# Altium schematic sheet P01_Power.SchDoc
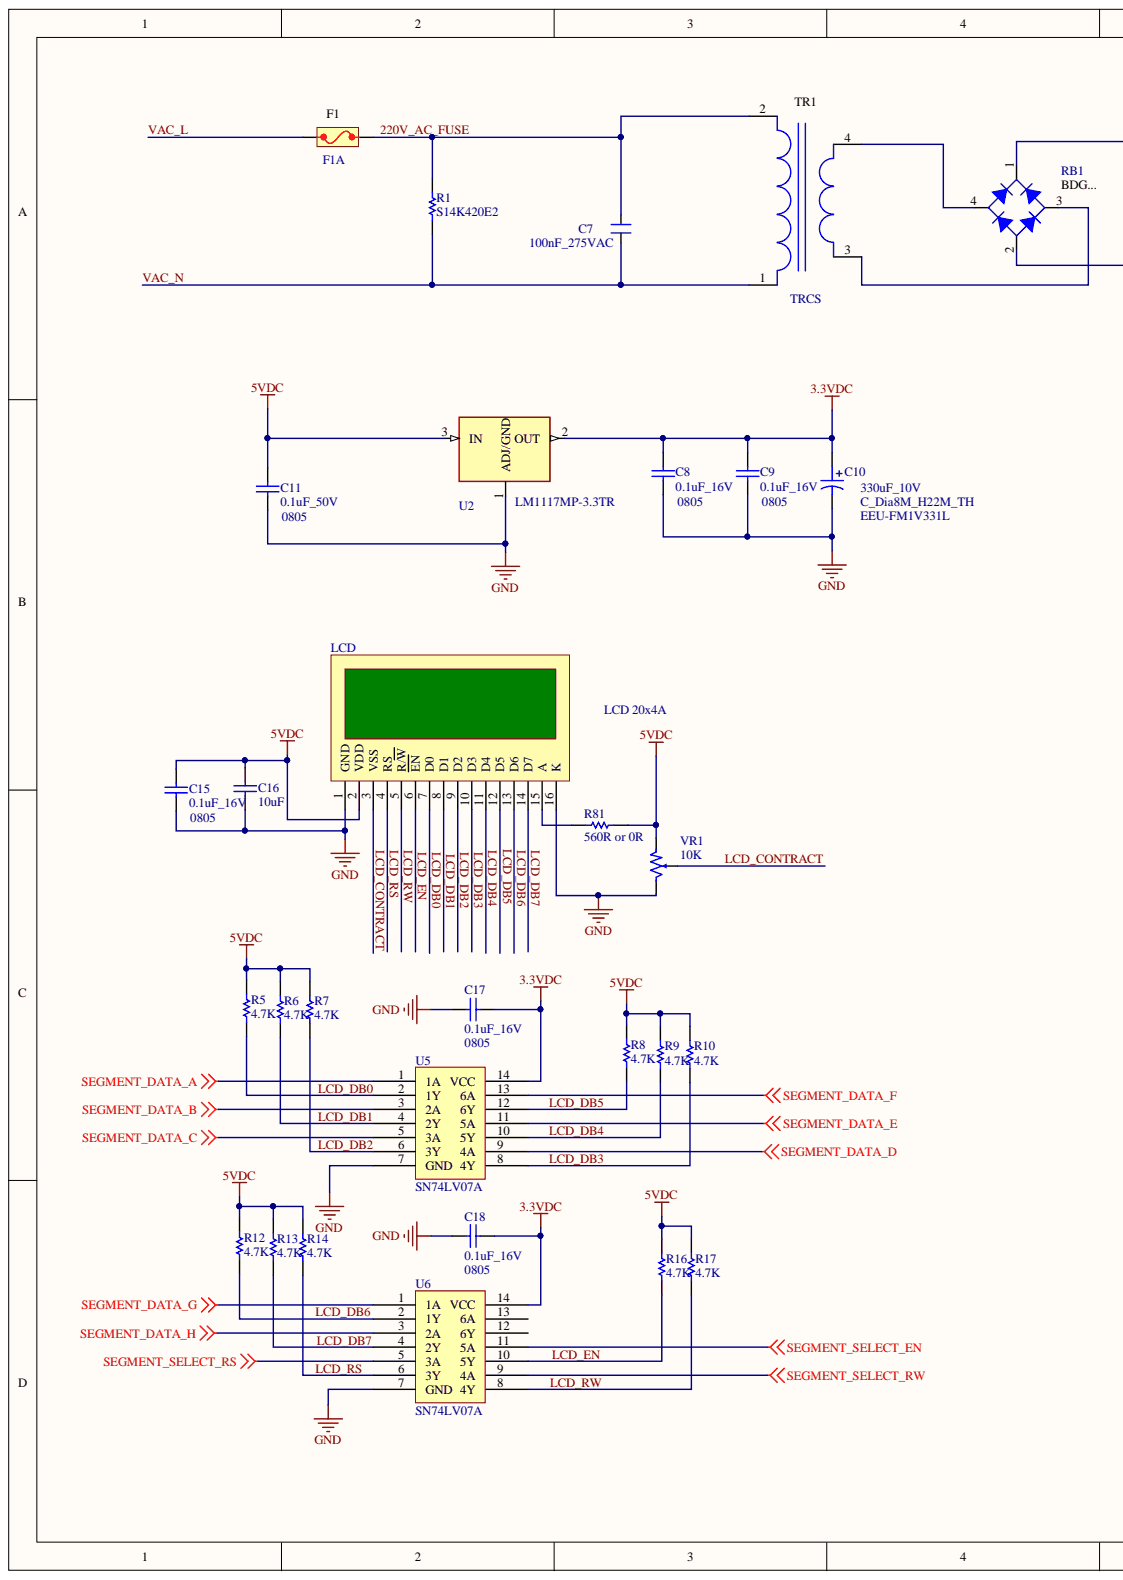
[diagram p0: P01_Power.SchDoc, left]
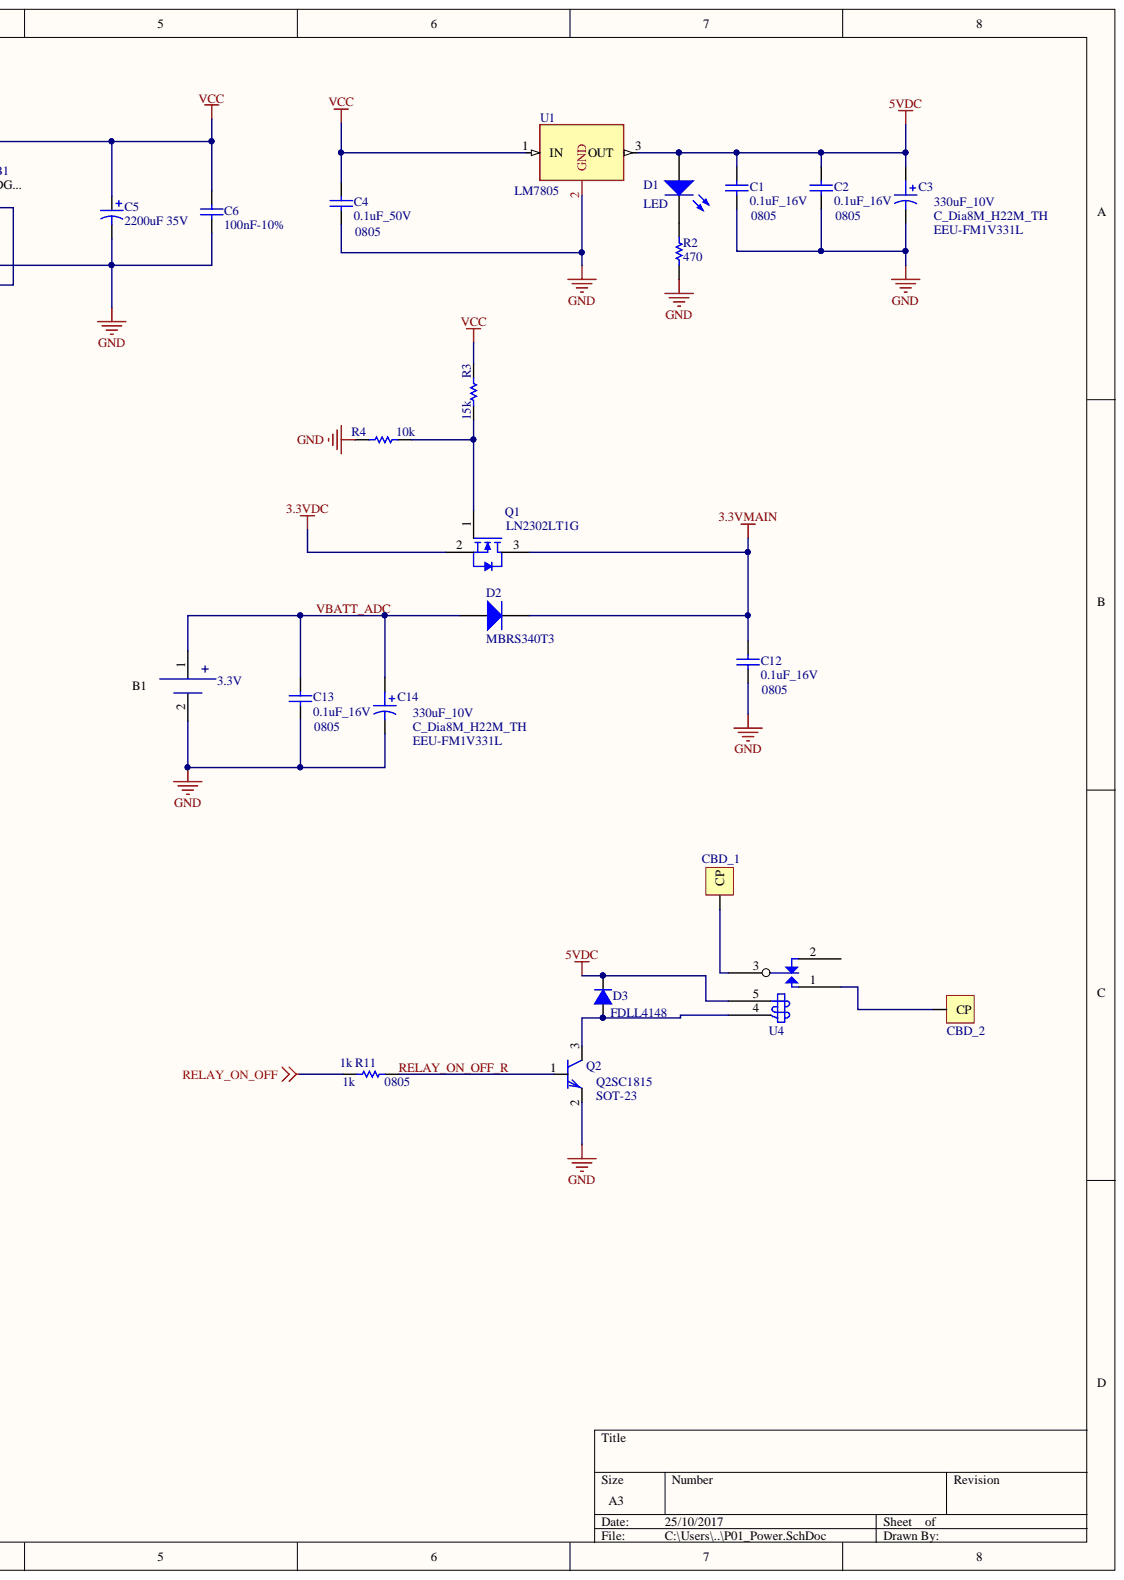
[diagram p1: P01_Power.SchDoc, right]

## Parts
B1  value 3.3V  [Pin]  (hidden comment: BATT-Holder)
C1  0.1uF_16V  PCB_Footprint: 0805  [C-NP-0805]
C2  0.1uF_16V  PCB_Footprint: 0805  [C-NP-0805]
C3  MFR_PART: EEU-FM1V331L  Part: 330uF_10V  PCB_Footprint: C_Dia8M_H22M_TH  [CAP 470MF/16V]  (hidden comment: 330uF_35V)
C4  0.1uF_50V  PCB_Footprint: 0805  [C-NP-0805]
C5  2200uF 35V  [CAP 4700MF/16V]
C6  100nF-10%  [C-NP-0805]
C7  100nF_275VAC  [Res 10mm (1/2W)]
C8  0.1uF_16V  PCB_Footprint: 0805  [C-NP-0805]
C9  0.1uF_16V  PCB_Footprint: 0805  [C-NP-0805]
C10  MFR_PART: EEU-FM1V331L  Part: 330uF_10V  PCB_Footprint: C_Dia8M_H22M_TH  [CAP 470MF/16V]  (hidden comment: 330uF_35V)
C11  0.1uF_50V  PCB_Footprint: 0805  [C-NP-0805]
C12  0.1uF_16V  PCB_Footprint: 0805  [C-NP-0805]
C13  0.1uF_16V  PCB_Footprint: 0805  [C-NP-0805]
C14  MFR_PART: EEU-FM1V331L  Part: 330uF_10V  PCB_Footprint: C_Dia8M_H22M_TH  [CAP 470MF/16V]  (hidden comment: 330uF_35V)
C15  0.1uF_16V  PCB_Footprint: 0805  [C-NP-0805]
C16  10uF  [C-NP-0805]
C17  0.1uF_16V  PCB_Footprint: 0805  [C-NP-0805]
C18  0.1uF_16V  PCB_Footprint: 0805  [C-NP-0805]
CBD_1  Connect Pad  [CP]  (value not drawn)
CBD_2  Connect Pad  [CP]  (value not drawn)
D1  LED  [LED-0805 BLUE]
D2  MBRS340T3  [DO-214AA,SMB]
D3  FDLL4148  [SOD80]
F1  FUSE  value F1A  [FUSE radial - D8.5-H7.5]
LCD  LCD 20x4A  [LCD20x4]
Q1  LN2302LT1G  [SOT-23]
Q2  C1815  [SOT-23]
R1  S14K420E2  [Resistor]
R2  470  [R-0805]
R3  15k  [R-0805]
R4  10k  [R-0805]
R5  4.7K  [R-0805]
R6  4.7K  [R-0805]
R7  4.7K  [R-0805]
R8  4.7K  [R-0805]
R9  4.7K  [R-0805]
R10  4.7K  [R-0805]
R11  1k  PCB_Footprint: 0805  [R-0805]
R12  4.7K  [R-0805]
R13  4.7K  [R-0805]
R14  4.7K  [R-0805]
R16  4.7K  [R-0805]
R17  4.7K  [R-0805]
R81  value 560R or 0R  [R-0805]  (hidden comment: Resistor)
RB1  value BDG...  [DB107S]  (hidden comment: Diode Bridge)
TR1  TRCS  [TR12V]
U1  LM7805  [TO-220]
U2  LM1117MP-3.3TR  [SOT-223 3-Lead]
U4  RELAY5V  [Relay5V]  (value not drawn)
U5  SN74LV07A  [SOIC14]
U6  SN74LV07A  [SOIC14]
VR1  10K  [Trimmer]

## Nets
3.3VDC: C8.2 C9.2 C10.1 C17.1 C18.1 Q1.2 U2.2 U5.14(VCC) U6.14(VCC)
3.3VMAIN: C12.2 D2.2 Q1.3
5VDC: C1.2 C2.2 C3.1 C11.2 C15.2 C16.2 D1.1 D3.2 LCD.2(VDD) R5.2 R6.2 R7.2 R8.2 R9.2 R10.2 R12.2 R13.2 R14.2 R16.2 R17.2 R81.2 U1.3 U2.3 U4.5 VR1.1
GND: B1.2 C1.1 C2.1 C3.2 C4.1 C5.2 C6.1 C8.1 C9.1 C10.2 C11.1 C12.1 C13.1 C14.2 C15.1 C16.1 C17.2 C18.2 LCD.1(GND) LCD.16(K) Q2.2 R2.1 R4.1 RB1.2 U1.2 U2.1 U5.7(GND) U6.7(GND) VR1.3
RELAY_ON_OFF: R11.1
SEGMENT_DATA_A: U5.1(1A)
SEGMENT_DATA_B: U5.3(2A)
SEGMENT_DATA_C: U5.5(3A)
SEGMENT_DATA_D: U5.9(4A)
SEGMENT_DATA_E: U5.11(5A)
SEGMENT_DATA_F: U5.13(6A)
SEGMENT_DATA_G: U6.1(1A)
SEGMENT_DATA_H: U6.3(2A)
SEGMENT_SELECT_EN: U6.11(5A)
SEGMENT_SELECT_RS: U6.5(3A)
SEGMENT_SELECT_RW: U6.9(4A)
VCC: C4.2 C5.1 C6.2 R3.1 RB1.1 U1.1
220V_AC_FUSE: C7.2 F1.2 R1.1 TR1.2
LCD_CONTRACT: LCD.3(VSS) VR1.2
LCD_DB0: LCD.7(D0) R5.1 U5.2(1Y)
LCD_DB1: LCD.8(D1) R6.1 U5.4(2Y)
LCD_DB2: LCD.9(D2) R7.1 U5.6(3Y)
LCD_DB3: LCD.10(D3) R10.1 U5.8(4Y)
LCD_DB4: LCD.11(D4) R9.1 U5.10(5Y)
LCD_DB5: LCD.12(D5) R8.1 U5.12(6Y)
LCD_DB6: LCD.13(D6) R12.1 U6.2(1Y)
LCD_DB7: LCD.14(D7) R13.1 U6.4(2Y)
LCD_EN: LCD.6(EN) R16.1 U6.10(5Y)
LCD_RS: LCD.4(RS) R14.1 U6.6(3Y)
LCD_RW: LCD.5(R/W) R17.1 U6.8(4Y)
RELAY_ON_OFF_R: Q2.1 R11.2
VAC_L: F1.1
VAC_N: C7.1 R1.2 TR1.1
VBATT_ADC: B1.1 C13.2 C14.1 D2.1
NetCBD_1_1: CBD_1.1 U4.3
NetCBD_2_1: CBD_2.1 U4.1
NetD1_2: D1.2 R2.2
NetD3_1: D3.1 Q2.3 U4.4
NetLCD_15: LCD.15(A) R81.1
NetQ1_1: Q1.1 R3.2 R4.2
NetRB1_3: RB1.3 TR1.3
NetRB1_4: RB1.4 TR1.4

Not connected: U4 2; U6 12(6Y) 13(6A)

## Library data of the parts
B1 = BATT-Holder: Battery holder; Manufacturer: China; Vendor: Digikey; Component Kind: Standard; ComponentLink1Description: Digikey Part Link; ComponentLink2Description: Open Datasheet; Price: 3000 VND; Published: 13-April-2009; ComponentLink2URL: H:\LIBRARY\LIBRARY.Datasheet\; Library Name: hphonglib.SchLib; Publisher: Hoang Nguyen
C1 C2 C4 C8 C9 C11-C13 C15 C17 C18 = Cap Non-pol: Non-polarized Capacitor; Component Kind: Standard; Library Name: hphonglib.SchLib
  C1 C2 C8 C9 C12 C13 C15 C17 C18: Part: 0.1uF_16V
  C4 C11: Part: 0.1uF_50V
C3 C10 C14 = Cap Pol: Polarized Capacitor; Component Kind: Standard; Library Name: hphonglib.SchLib
C5 = 4.7uF-50V-Tantalum: CAP ALUM 2.2UF 400V 20% RADIAL; Manufacturer: Nichicon; Manufacturer Part Number: UVY2G2R2MPD1TA; Component Kind: Standard; Digi-Key Part Number: UVY2G2R2MPD1TA-ND; Library Name: Capacitor.SchLib; Unit Price: 0.07
C6 = 100nF-50V-C0805: Non-Polarized Capacitor; Manufacturer: Yageo; Manufacturer Part Number: CC0805KRX7R9BB104; Component Kind: Standard; Digi-Key Part Number: 311-1140-1-ND; Library Name: Capacitor.SchLib; Unit Price: 0.1; Value: 100nF-10%
C7 = CM: Cap SMD; Component Kind: Standard; Library Name: syhaunguyen.SchLib
C16 = 100nF-50V-C0805: Non-Polarized Capacitor; Manufacturer: Yageo; Manufacturer Part Number: CC0805KRX7R9BB104; Value: 1uF; Component Kind: Standard; Digi-Key Part Number: 311-1140-1-ND; Library Name: Capacitor.SchLib; Unit Price: 0.1
CBD_1 CBD_2 = Connect Pad: Connect Pad, 1-Pin; Component Kind: Standard; LatestRevisionDate: 17-Jul-2002; LatestRevisionNote: Re-released for DXP Platform.; Library Name: phong.SchLib; Publisher: Altium Limited
D1 = LED: Typical LED; Component Kind: Standard; LatestRevisionDate: 08-Jul-2005; LatestRevisionNote: Mech Layer 1 removed.; PackageReference: LED-1; Published: 8-Jun-2000; Library Name: hphonglib.SchLib; Publisher: Altium Limited
D2 = Diode 1N4148: High Conductance Fast Diode; Code_JEDEC: DO-204-AH; Component Kind: Standard; ComponentLink1Description: Manufacturer Link; ComponentLink1URL: http://www.fairchildsemi.com/; ComponentLink2Description: Datasheet; ComponentLink2URL: http://www.fairchildsemi.com/ds/1N%2F1N4148.pdf; DatasheetVersion: 1997; LatestRevisionDate: 17-Jul-2002; LatestRevisionNote: Re-released for DXP Platform.; PackageDescription: Diode, Axial; Body 3.8 x 1.9 mm (LxDia. max), Lead Dia. 0.53 mm (max); PackageReference: DO-35; Published: 8-Jun-2000; Signal Integrity: 1N4148; Simulation: 1N4148; Library Name: hphonglib.SchLib; Publisher: Altium Limited
D3 = FDLL4148: DIODE GEN PURP 100V 200MA LL34; Manufacturer: Fairchild Semiconductor; Capacitance @ Vr, F: 4pF @ 0V, 1MHz; Current - Reverse Leakage @ Vr: 5µA @ 75V; Diode Type: Standard; Reverse Recovery Time (trr): 4ns; Speed: Small Signal =< 200mA (Io), Any Speed; Voltage - Forward (Vf) (Max) @ If: 1V @ 10mA; Category: Discrete Semiconductor Products; Component Kind: Standard; ComponentLink1Description: http://www.fairchildsemi.com/datasheets/FD/FDLL4148.pdf; ComponentLink1URL: http://www.fairchildsemi.com/datasheets/FD/FDLL4148.pdf; Current - Average Rectified (Io): 200mA; Library Name: Diode.SchLib; Manufacturer Part Number: FDLL4148; Mounting Type: Surface Mount; Operating Temperature - Junction: -65°C ~ 175°C; Package / Case: DO-213AC, MINI-MELF, SOD-80; Packaging: Cut Tape (CT); Pricing 1: 1=0.1, 10=0.091, 100=0.0494, 500=0.03036, 1000=0.0207 (USD); RoHS: RoHS Compliant; Stock 1: 286836; Supplier 1: Digi-Key; Supplier Device Package: LL-34; Supplier Part Number 1: FDLL4148CT-ND; Voltage - DC Reverse (Vr) (Max): 100V
F1 = FUSE_1: Vendor: Digikey; Component Kind: Standard; ComponentLink1Description: Digikey Part Link; ComponentLink2Description: Open Datasheet; Description: FUSE; Published: 13-April-2009; ComponentLink2URL: H:\LIBRARY\LIBRARY.Datasheet\; Library Name: hphonglib.SchLib; Publisher: Hoang Nguyen
LCD = LCD20x4A: LCD dipslay 20 char, 4 line; Value: Jum 40; Component Kind: Standard; Value: LCD 20x4A; Library Name: syhaunguyen.SchLib
Q1 = 2N7002: N-Channel Enhancement Mode FET; Vendor: Digikey; Component Kind: Standard; ComponentLink1Description: Digikey Part Link; ComponentLink2Description: Open Datasheet; DatasheetDocument: Rev A1, 1997; Published: 5-Jan-2004; ComponentLink2URL: H:\LIBRARY\LIBRARY.Datasheet\; Library Name: hphonglib.SchLib; Publisher: Altium Limited
Q2 = C1815: Q2SC1815; Component Kind: Standard; Part: Q2SC1815; PCB_Footprint: SOT-23; Simulation: Q2SC1815; Library Name: hphonglib.SchLib
R1-R14 R16 R17 = Resistor: Component Kind: Standard; Library Name: hphonglib.SchLib
  R1: Description: Resistor; LatestRevisionDate: 17-Jul-2002; LatestRevisionNote: Re-released for DXP Platform.; PackageReference: AXIAL-0.3; Published: 8-Jun-2000; Value: S14K420E2
  R2: Description: Resistor; LatestRevisionDate: 17-Jul-2002; LatestRevisionNote: Re-released for DXP Platform.; PackageReference: AXIAL-0.3; Published: 8-Jun-2000; Value: 470
  R3: Description: Resistor; LatestRevisionDate: 17-Jul-2002; LatestRevisionNote: Re-released for DXP Platform.; PackageReference: AXIAL-0.3; Published: 8-Jun-2000; Value: 15k
  R4: Description: Resistor; LatestRevisionDate: 17-Jul-2002; LatestRevisionNote: Re-released for DXP Platform.; PackageReference: AXIAL-0.3; Published: 8-Jun-2000; Value: 10k
  R5-R10 R12-R14 R16 R17: Description: Resistor; LatestRevisionDate: 17-Jul-2002; LatestRevisionNote: Re-released for DXP Platform.; PackageReference: AXIAL-0.3; Published: 8-Jun-2000; Value: 4.7K
  R11: Part: 1k
  R1-R10 R12-R14 R16 R17: Publisher: Altium Limited
RB1 = Diode_Bridge: Rectifier Bridge; Vendor: Digikey; Component Kind: Standard; ComponentLink1Description: Digikey Part Link; ComponentLink1URL: http://www.irf.com/; ComponentLink2Description: Open Datasheet; ComponentLink2URL: http://www.irf.com/product-info/datasheets/data/1kab-e.pdf; DatasheetDocument: E2732B; LatestRevisionDate: 17-Jul-2002; LatestRevisionNote: Re-released for DXP Platform.; PackageReference: D-38; Published: 8-Jun-2000; Library Name: phong.SchLib; Publisher: Altium Limited
TR1 = TRCS: Power 3VA - Body 40x32 mm; Vendor: Digikey; Component Kind: Standard; ComponentLink1Description: Digikey Part Link; ComponentLink2Description: Open Datasheet; Published: 13-April-2009; ComponentLink2URL: H:\LIBRARY\LIBRARY.Datasheet\; Library Name: phong.SchLib; Publisher: Hoang Nguyen
U1 = LM78xx: Regulator; Manufacturer: Fairchild Semiconductor Corporation; Output Current: Up to 1A; PSpiceTemplate: X^@REFDES %IN %OUT %GND @MODEL; Tolerance: 4 %; Value: LM7805CT; Vendor: Digikey; V out: 5V; Component Kind: Standard; ComponentLink1Description: Digikey Part Link; ComponentLink2Description: Open Datasheet; Price: 2500 VND; Published: 13-April-2009; Simulation: LM7805C; ComponentLink2URL: H:\LIBRARY\LIBRARY.Datasheet\LM7805.pdf; Library Name: phong.SchLib; Publisher: Hoang Nguyen
U2 = LM1117MP-3.3TR: 3.3V 800mA Low-Dropout Linear Regulator; PSpiceTemplate: X^@REFDES %IN %OUT %GND @MODEL; Vendor: Digikey; Volt (Max): 20V; Component Kind: Standard; ComponentLink1Description: Digikey Part Link; ComponentLink1URL: http://search.digikey.com/scripts/DkSearch/dksus.dll?Detail&name=LM1117MP-3.3TR-ND; ComponentLink2Description: Open Datasheet; Output Current (max): 800mA; Price: 4.000 VND; Published: 13-April-2009; V out: 3.3V; ComponentLink2URL: H:\LIBRARY\LIBRARY.Datasheet\LM1117.pdf; Library Name: hphonglib.SchLib; Publisher: Hoang Nguyen
U4 = RELAY5V: Ro le 5V; Vendor: Digikey; Component Kind: Standard; ComponentLink1Description: Digikey Part Link; ComponentLink2Description: Open Datasheet; Published: 13-April-2009; ComponentLink2URL: H:\LIBRARY\LIBRARY.Datasheet\; Library Name: hphonglib.SchLib; Publisher: Hoang Nguyen
U5 U6 = 74HC04P1: Component Kind: Standard; Library Name: 74HC04P1.SchLib
VR1 = VR1: Var Resistor; Value: VR 10K; Component Kind: Standard; Library Name: syhaunguyen.SchLib
R81 = Resistor: Component Kind: Standard; Description: Resistor; LatestRevisionDate: 17-Jul-2002; LatestRevisionNote: Re-released for DXP Platform.; PackageReference: AXIAL-0.3; Published: 8-Jun-2000; Library Name: hphonglib.SchLib; Publisher: Altium Limited
